# Altium schematic sheet retroBreadboard_v1i1.SchDoc
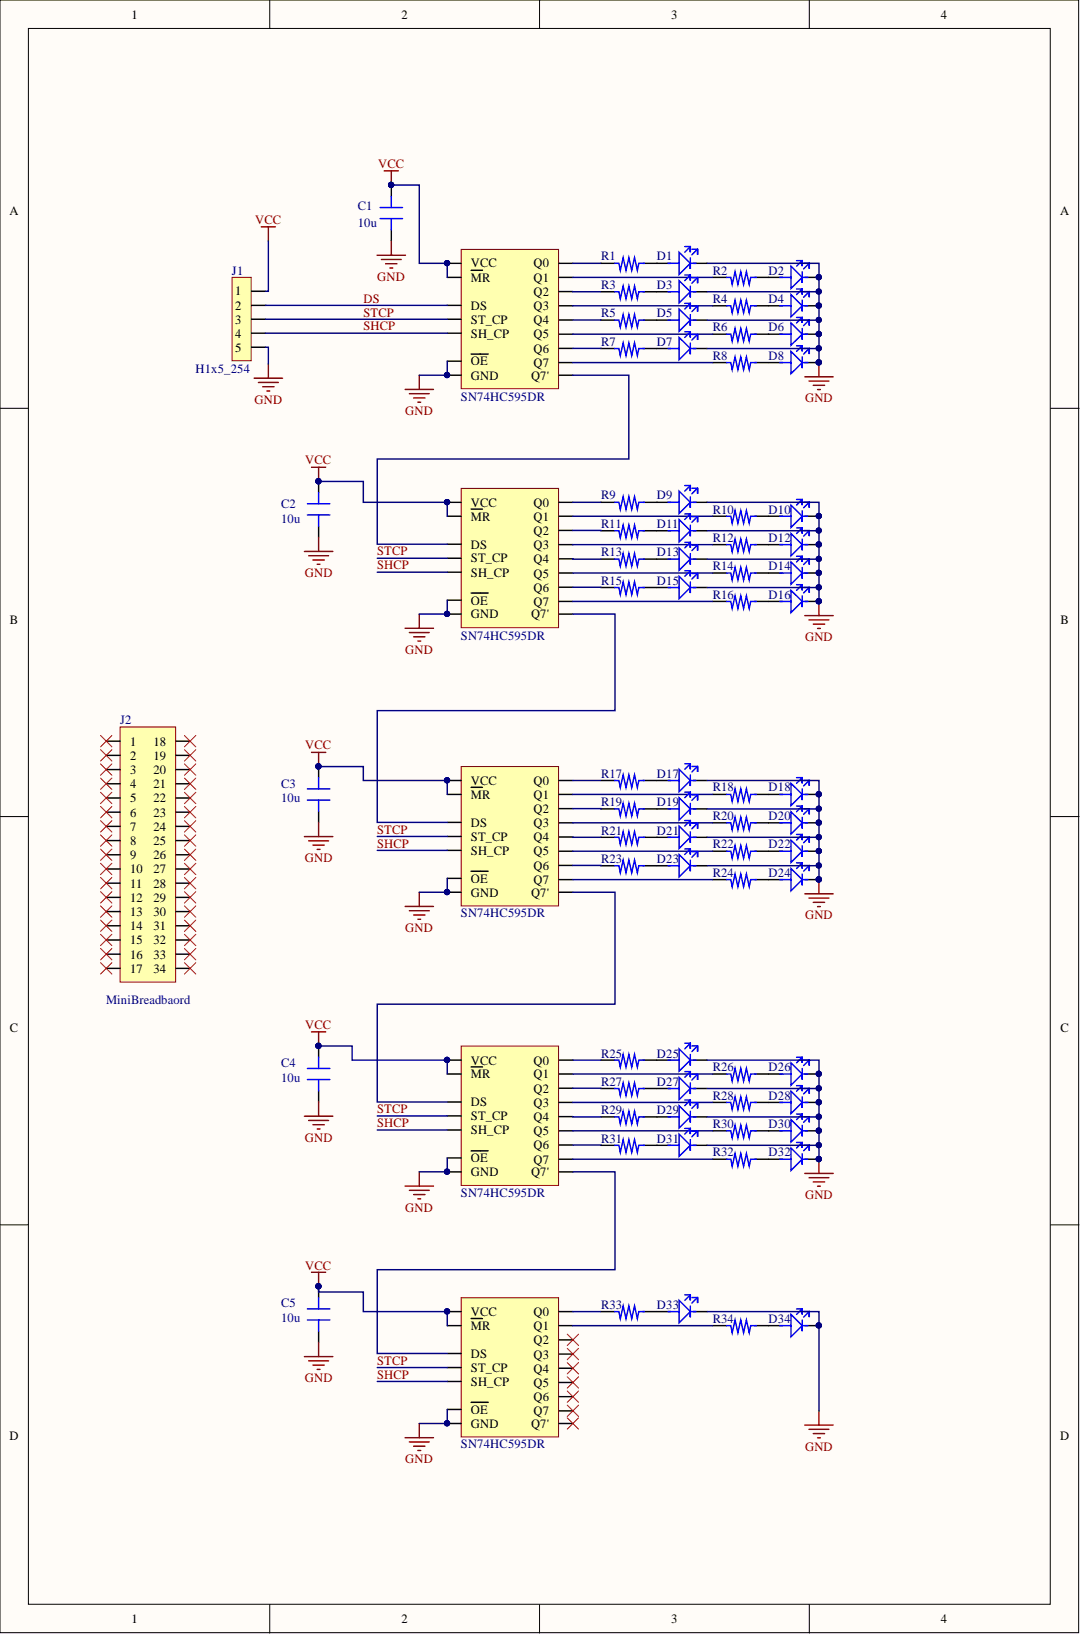
[diagram p0: retroBreadboard_v1i1.SchDoc]

## Parts
C1  10u  [C1608]  (no BOM)
C2  10u  [C1608]  (no BOM)
C3  10u  [C1608]  (no BOM)
C4  10u  [C1608]  (no BOM)
C5  10u  [C1608]  (no BOM)
D1  VLMB1500-GS08  [VLMB1500-GS08]  (value not drawn)
D2  VLMB1500-GS08  [VLMB1500-GS08]  (value not drawn)
D3  VLMB1500-GS08  [VLMB1500-GS08]  (value not drawn)
D4  VLMB1500-GS08  [VLMB1500-GS08]  (value not drawn)
D5  VLMB1500-GS08  [VLMB1500-GS08]  (value not drawn)
D6  VLMB1500-GS08  [VLMB1500-GS08]  (value not drawn)
D7  VLMB1500-GS08  [VLMB1500-GS08]  (value not drawn)
D8  VLMB1500-GS08  [VLMB1500-GS08]  (value not drawn)
D9  VLMB1500-GS08  [VLMB1500-GS08]  (value not drawn)
D10  VLMB1500-GS08  [VLMB1500-GS08]  (value not drawn)
D11  VLMB1500-GS08  [VLMB1500-GS08]  (value not drawn)
D12  VLMB1500-GS08  [VLMB1500-GS08]  (value not drawn)
D13  VLMB1500-GS08  [VLMB1500-GS08]  (value not drawn)
D14  VLMB1500-GS08  [VLMB1500-GS08]  (value not drawn)
D15  VLMB1500-GS08  [VLMB1500-GS08]  (value not drawn)
D16  VLMB1500-GS08  [VLMB1500-GS08]  (value not drawn)
D17  VLMB1500-GS08  [VLMB1500-GS08]  (value not drawn)
D18  VLMB1500-GS08  [VLMB1500-GS08]  (value not drawn)
D19  VLMB1500-GS08  [VLMB1500-GS08]  (value not drawn)
D20  VLMB1500-GS08  [VLMB1500-GS08]  (value not drawn)
D21  VLMB1500-GS08  [VLMB1500-GS08]  (value not drawn)
D22  VLMB1500-GS08  [VLMB1500-GS08]  (value not drawn)
D23  VLMB1500-GS08  [VLMB1500-GS08]  (value not drawn)
D24  VLMB1500-GS08  [VLMB1500-GS08]  (value not drawn)
D25  VLMB1500-GS08  [VLMB1500-GS08]  (value not drawn)
D26  VLMB1500-GS08  [VLMB1500-GS08]  (value not drawn)
D27  VLMB1500-GS08  [VLMB1500-GS08]  (value not drawn)
D28  VLMB1500-GS08  [VLMB1500-GS08]  (value not drawn)
D29  VLMB1500-GS08  [VLMB1500-GS08]  (value not drawn)
D30  VLMB1500-GS08  [VLMB1500-GS08]  (value not drawn)
D31  VLMB1500-GS08  [VLMB1500-GS08]  (value not drawn)
D32  VLMB1500-GS08  [VLMB1500-GS08]  (value not drawn)
D33  VLMB1500-GS08  [VLMB1500-GS08]  (value not drawn)
D34  VLMB1500-GS08  [VLMB1500-GS08]  (value not drawn)
J1  H1x5_254  [HEADER_1x5_254]
J2  MiniBreadbaord  [MINI_BREADBOARD]
R1  RR0510P-101-D  [RES0402]  (value not drawn)
R2  RR0510P-101-D  [RES0402]  (value not drawn)
R3  RR0510P-101-D  [RES0402]  (value not drawn)
R4  RR0510P-101-D  [RES0402]  (value not drawn)
R5  RR0510P-101-D  [RES0402]  (value not drawn)
R6  RR0510P-101-D  [RES0402]  (value not drawn)
R7  RR0510P-101-D  [RES0402]  (value not drawn)
R8  RR0510P-101-D  [RES0402]  (value not drawn)
R9  RR0510P-101-D  [RES0402]  (value not drawn)
R10  RR0510P-101-D  [RES0402]  (value not drawn)
R11  RR0510P-101-D  [RES0402]  (value not drawn)
R12  RR0510P-101-D  [RES0402]  (value not drawn)
R13  RR0510P-101-D  [RES0402]  (value not drawn)
R14  RR0510P-101-D  [RES0402]  (value not drawn)
R15  RR0510P-101-D  [RES0402]  (value not drawn)
R16  RR0510P-101-D  [RES0402]  (value not drawn)
R17  RR0510P-101-D  [RES0402]  (value not drawn)
R18  RR0510P-101-D  [RES0402]  (value not drawn)
R19  RR0510P-101-D  [RES0402]  (value not drawn)
R20  RR0510P-101-D  [RES0402]  (value not drawn)
R21  RR0510P-101-D  [RES0402]  (value not drawn)
R22  RR0510P-101-D  [RES0402]  (value not drawn)
R23  RR0510P-101-D  [RES0402]  (value not drawn)
R24  RR0510P-101-D  [RES0402]  (value not drawn)
R25  RR0510P-101-D  [RES0402]  (value not drawn)
R26  RR0510P-101-D  [RES0402]  (value not drawn)
R27  RR0510P-101-D  [RES0402]  (value not drawn)
R28  RR0510P-101-D  [RES0402]  (value not drawn)
R29  RR0510P-101-D  [RES0402]  (value not drawn)
R30  RR0510P-101-D  [RES0402]  (value not drawn)
R31  RR0510P-101-D  [RES0402]  (value not drawn)
R32  RR0510P-101-D  [RES0402]  (value not drawn)
R33  RR0510P-101-D  [RES0402]  (value not drawn)
R34  RR0510P-101-D  [RES0402]  (value not drawn)
U1  SN74HC595DR  [SOIC16]
U2  SN74HC595DR  [SOIC16]
U3  SN74HC595DR  [SOIC16]
U4  SN74HC595DR  [SOIC16]
U5  SN74HC595DR  [SOIC16]

## Nets
GND: C1.2 C2.2 C3.2 C4.2 C5.2 D1.2 D2.2 D3.2 D4.2 D5.2 D6.2 D7.2 D8.2 D9.2 D10.2 D11.2 D12.2 D13.2 D14.2 D15.2 D16.2 D17.2 D18.2 D19.2 D20.2 D21.2 D22.2 D23.2 D24.2 D25.2 D26.2 D27.2 D28.2 D29.2 D30.2 D31.2 D32.2 D33.2 D34.2 J1.5 U1.8(GND) U1.13(OE) U2.8(GND) U2.13(OE) U3.8(GND) U3.13(OE) U4.8(GND) U4.13(OE) U5.8(GND) U5.13(OE)
VCC: C1.1 C2.1 C3.1 C4.1 C5.1 J1.1 U1.10(MR) U1.16(VCC) U2.10(MR) U2.16(VCC) U3.10(MR) U3.16(VCC) U4.10(MR) U4.16(VCC) U5.10(MR) U5.16(VCC)
DS: J1.2 U1.14(DS)
SHCP: J1.4 U1.11(SH_CP) U2.11(SH_CP) U3.11(SH_CP) U4.11(SH_CP) U5.11(SH_CP)
STCP: J1.3 U1.12(ST_CP) U2.12(ST_CP) U3.12(ST_CP) U4.12(ST_CP) U5.12(ST_CP)
NetD1_1: D1.1 R1.1
NetD2_1: D2.1 R2.1
NetD3_1: D3.1 R3.1
NetD4_1: D4.1 R4.1
NetD5_1: D5.1 R5.1
NetD6_1: D6.1 R6.1
NetD7_1: D7.1 R7.1
NetD8_1: D8.1 R8.1
NetD9_1: D9.1 R9.1
NetD10_1: D10.1 R10.1
NetD11_1: D11.1 R11.1
NetD12_1: D12.1 R12.1
NetD13_1: D13.1 R13.1
NetD14_1: D14.1 R14.1
NetD15_1: D15.1 R15.1
NetD16_1: D16.1 R16.1
NetD17_1: D17.1 R17.1
NetD18_1: D18.1 R18.1
NetD19_1: D19.1 R19.1
NetD20_1: D20.1 R20.1
NetD21_1: D21.1 R21.1
NetD22_1: D22.1 R22.1
NetD23_1: D23.1 R23.1
NetD24_1: D24.1 R24.1
NetD25_1: D25.1 R25.1
NetD26_1: D26.1 R26.1
NetD27_1: D27.1 R27.1
NetD28_1: D28.1 R28.1
NetD29_1: D29.1 R29.1
NetD30_1: D30.1 R30.1
NetD31_1: D31.1 R31.1
NetD32_1: D32.1 R32.1
NetD33_1: D33.1 R33.1
NetD34_1: D34.1 R34.1
NetR1_2: R1.2 U1.15(Q0)
NetR2_2: R2.2 U1.1(Q1)
NetR3_2: R3.2 U1.2(Q2)
NetR4_2: R4.2 U1.3(Q3)
NetR5_2: R5.2 U1.4(Q4)
NetR6_2: R6.2 U1.5(Q5)
NetR7_2: R7.2 U1.6(Q6)
NetR8_2: R8.2 U1.7(Q7)
NetR9_2: R9.2 U2.15(Q0)
NetR10_2: R10.2 U2.1(Q1)
NetR11_2: R11.2 U2.2(Q2)
NetR12_2: R12.2 U2.3(Q3)
NetR13_2: R13.2 U2.4(Q4)
NetR14_2: R14.2 U2.5(Q5)
NetR15_2: R15.2 U2.6(Q6)
NetR16_2: R16.2 U2.7(Q7)
NetR17_2: R17.2 U3.15(Q0)
NetR18_2: R18.2 U3.1(Q1)
NetR19_2: R19.2 U3.2(Q2)
NetR20_2: R20.2 U3.3(Q3)
NetR21_2: R21.2 U3.4(Q4)
NetR22_2: R22.2 U3.5(Q5)
NetR23_2: R23.2 U3.6(Q6)
NetR24_2: R24.2 U3.7(Q7)
NetR25_2: R25.2 U4.15(Q0)
NetR26_2: R26.2 U4.1(Q1)
NetR27_2: R27.2 U4.2(Q2)
NetR28_2: R28.2 U4.3(Q3)
NetR29_2: R29.2 U4.4(Q4)
NetR30_2: R30.2 U4.5(Q5)
NetR31_2: R31.2 U4.6(Q6)
NetR32_2: R32.2 U4.7(Q7)
NetR33_2: R33.2 U5.15(Q0)
NetR34_2: R34.2 U5.1(Q1)
NetU1_9: U1.9(Q7') U2.14(DS)
NetU2_9: U2.9(Q7') U3.14(DS)
NetU3_9: U3.9(Q7') U4.14(DS)
NetU4_9: U4.9(Q7') U5.14(DS)

Not connected: J2 1 2 3 4 5 6 7 8 9 10 11 12 13 14 15 16 17 18 19 20 21 22 23 24 25 26 27 28 29 30 31 32 33 34; U5 2(Q2) 3(Q3) 4(Q4) 5(Q5) 6(Q6) 7(Q7) 9(Q7')

## Library data of the parts
C1-C5 = C805_10u: Description: 10u; Library Name: retroBreadboard_v1i1.SchLib
D1-D34 = VLMB1500-GS08: Standard LEDs - SMD 0402 BLUE Non-Diff; Manufacturer: Vishay; Dominant Wavelength: 470nm; Forward Current: 30mA; Forward Voltage: 3.15V; Lens Colour: Clear; Lens Style: Rectangular; Luminous Intensity: 45mcd; Max Power Dissipation: 76mW; Number of Elements: 1; Power Rating: 75mW; Test Current: 5mA; Viewing Angle: 130°; Case/Package: 0402; Case Code (Imperial): 0402; Case Code (Metric): 1005; Colour: Blue; Component Kind: Standard; ComponentLink1Description: http://www.vishay.com/docs/82554/vlmo1500.pdf; ComponentLink1URL: http://www.vishay.com/docs/82554/vlmo1500.pdf; Contact Plating: Matte,Tin; Depth: 0.5mm; Height: 0.35mm; Illumination Colour: Blue; Lead Free: Lead Free; Length: 1mm; Manufacturer Part Number: VLMB1500-GS08; Max Operating Temperature: 80°C; Min Operating Temperature: -30°C; Mount: Surface Mount; Number of LEDs: 1; Number of Pins: 2; Package Quantity: 1; Packaging: Tape and Reel; Power Dissipation: 76mW; Pricing 1: 1=0.41, 10=0.292, 25=0.2532, 100=0.1949, 250=0.15836, 500=0.11696, 1000=0.09746 (USD); Radiation Hardening: No; REACH SVHC: Unknown; Stock 1: 322508; Supplier 1: Digi-Key; Supplier Part Number 1: VLMB1500-GS08DKR-ND; Width: 0.5mm; Library Name: retroBreadboard_v1i1.SchLib
J1 = H1x5_254: CONN HEADER 5POS .100 VERT GOLD; Manufacturer: Molex; Connector Type: Male Pin; Contact Resistance: 10mR; Current Rating: 4A; Gender: Male; Glow Wire Compliant: Non-compliant; Insulation Resistance: 50GR; Number of Circuits: 5; Pitch: 2.54mm; Voltage Rating: 250V; Colour: White; Component Kind: Standard; ComponentLink1Description: http://www.mouser.com/ds/2/276/0022102051_PCB_HEADERS-158417.pdf; ComponentLink1URL: http://www.mouser.com/ds/2/276/0022102051_PCB_HEADERS-158417.pdf; ComponentLink2Description: http://www.jameco.com/Jameco/Products/ProdDS/878921.pdf; ComponentLink2URL: http://www.jameco.com/Jameco/Products/ProdDS/878921.pdf; ComponentLink3Description: https://www.molex.com/pdm_docs/ps/PS-10-07-001.pdf; ComponentLink3URL: https://www.molex.com/pdm_docs/ps/PS-10-07-001.pdf; Contact Gender: Male; Contact Material: Brass; Contact Mating Length: 0.295inch; Contact Plating: Gold; Depth: 6.35mm; Flammability Rating: UL94 V-0; Halogen Free: Low Halogen; Height: 10.67mm; Housing Colour: White; Housing Material: Nylon; Length: 35.56mm; Manufacturer Part Number: 22-10-2051; Max Current Rating: 4A; Max Operating Temperature: 75°C; Min Operating Temperature: 0°C; Mount: Through Hole; Number of Contacts: 5; Number of Positions: 5; Number of Rows: 1; Orientation: Straight; Package Quantity: 10; Packaging: Bulk; Pricing 1: 1=0.99, 10=0.867, 100=0.748, 500=0.612, 1000=0.544, 5000=0.476, 10000=0.4522 (USD); Radiation Hardening: No; REACH SVHC: No SVHC; Stock 1: 6544; Supplier 1: Digi-Key; Supplier Part Number 1: WM2725-ND; Termination: Solder; Voltage Rating (DC): 250V; Library Name: retroBreadboard_v1i1.SchLib
J2 = 1738132-1: Mini Breadboard; Manufacturer: TE Connectivity AMP; Gender: Male; Colour: Natural; Component Kind: Standard; ComponentLink1Description: http://www.te.com/commerce/DocumentDelivery/DDEController?Action=srchrtrv&DocNm=1738132&DocType=Customer+Drawing&DocLang=English; ComponentLink1URL: http://www.te.com/commerce/DocumentDelivery/DDEController?Action=srchrtrv&DocNm=1738132&DocType=Customer+Drawing&DocLang=English; Manufacturer Part Number: 1738132-1; Stock 1: 0; Supplier 1: Digi-Key; Supplier Part Number 1: 1738132-1-ND; Library Name: retroBreadboard_v1i1.SchLib
R1-R34 = RR0510P-101-D: RES SMD 100 OHM 0.5% 1/16W 0402; Manufacturer: Susumu; Max Power Dissipation: 63mW; Resistance: 100R; Temperature Coefficient: 25ppm/°C; Voltage Rating: 25V; Case/Package: 0402; Case Code (Imperial): 0402; Case Code (Metric): 1005; Component Kind: Standard; ComponentLink1Description: http://www.susumu.co.jp/common/pdf/n_catalog_partition05_en.pdf; ComponentLink1URL: http://www.susumu.co.jp/common/pdf/n_catalog_partition05_en.pdf; ComponentLink2Description: http://www.farnell.com/datasheets/1581132.pdf; ComponentLink2URL: http://www.farnell.com/datasheets/1581132.pdf; Composition: Thin Film; Height: 0.016inch; Lead Free: Lead Free; Length: 0.039inch; Manufacturer Part Number: RR0510P-101-D; Max Operating Temperature: 125°C; Min Operating Temperature: -55°C; Number of Pins: 2; Number of Terminations: 2; Package Quantity: 1; Packaging: Tape and Reel; Power Rating: 63mW; Pricing 1: 1=0.1, 10=0.074, 100=0.0285, 1000=0.01254, 2500=0.01083, 5000=0.00969 (USD); REACH SVHC: Unknown; Stock 1: 596223; Supplier 1: Digi-Key; Supplier Part Number 1: RR05P100DDKR-ND; Termination: SMD/SMT; Tolerance: 0.5%; Voltage Rating (DC): 25V; Width: 0.02inch; Library Name: retroBreadboard_v1i1.SchLib
U1-U5 = 74HC595: IC, SM, LOGIC, 74HC, REGISTER; Manufacturer: Texas Instruments; Direction: Unidirectional; Frequency: 42MHz; Logic Function: Shift Register; Max Input Current: 1uA; Max Supply Voltage: 6V; Min Supply Voltage: 2V; Number of Bits: 8; Number of Circuits: 4; Number of Elements: 1; Number of Output Lines: 10; Operating Supply Voltage: 5V; Propagation Delay: 250ns; Quiescent Current: 8uA; Case/Package: SOIC; Component Kind: Standard; ComponentLink1Description: http://www.ti.com/lit/ds/symlink/sn74hc595.pdf; ComponentLink1URL: http://www.ti.com/lit/ds/symlink/sn74hc595.pdf; ComponentLink2Description: http://www.farnell.com/datasheets/1831198.pdf; ComponentLink2URL: http://www.farnell.com/datasheets/1831198.pdf; ComponentLink3Description: http://www.farnell.com/datasheets/1886142.pdf; ComponentLink3URL: http://www.farnell.com/datasheets/1886142.pdf; ComponentLink4Description: https://www.verical.com/server-webapp/html/getDatasheet?partID=134875; ComponentLink4URL: https://www.verical.com/server-webapp/html/getDatasheet?partID=134875; ComponentLink5Description: http://www.farnell.com/datasheets/1905810.pdf; ComponentLink5URL: http://www.farnell.com/datasheets/1905810.pdf; ComponentLink6Description: http://www.ti.com/general/docs/lit/getliterature.tsp?genericPartNumber=SN54HC595-SP&&fileType=pdf; ComponentLink6URL: http://www.ti.com/general/docs/lit/getliterature.tsp?genericPartNumber=SN54HC595-SP&&fileType=pdf; ComponentLink7Description: http://www.jameco.com/Jameco/Products/ProdDS/916385.pdf; ComponentLink7URL: http://www.jameco.com/Jameco/Products/ProdDS/916385.pdf; ComponentLink8Description: http://www.farnell.com/datasheets/2020588.pdf; ComponentLink8URL: http://www.farnell.com/datasheets/2020588.pdf; ComponentLink9Description: http://datasheet.iiic.cc/texas_instruments/SN74HC595DR.pdf; ComponentLink9URL: http://datasheet.iiic.cc/texas_instruments/SN74HC595DR.pdf; Contact Plating: Gold; Height: 1.58mm; Lead Free: Lead Free; Length: 9.9mm; Manufacturer Part Number: SN74HC595DR; Max Operating Temperature: 85°C; Min Operating Temperature: -40°C; Mount: Surface Mount; Number of Bits per Element: 8; Number of Pins: 16; Package Quantity: 2500; Packaging: Tape and Reel; Pricing 1: 1=0.51, 10=0.433, 100=0.323, 500=0.2538, 1000=0.19611 (USD); Radiation Hardening: No; REACH SVHC: No SVHC; Stock 1: 53061; Supplier 1: Digi-Key; Supplier Part Number 1: 296-14857-6-ND; Termination: SMD/SMT; Turn-On Delay Time: 250ns; Weight: 0.004998oz; Width: 3.91mm; Library Name: retroBreadboard_v1i1.SchLib
